# Altium schematic sheet MA730GQ.SchDoc
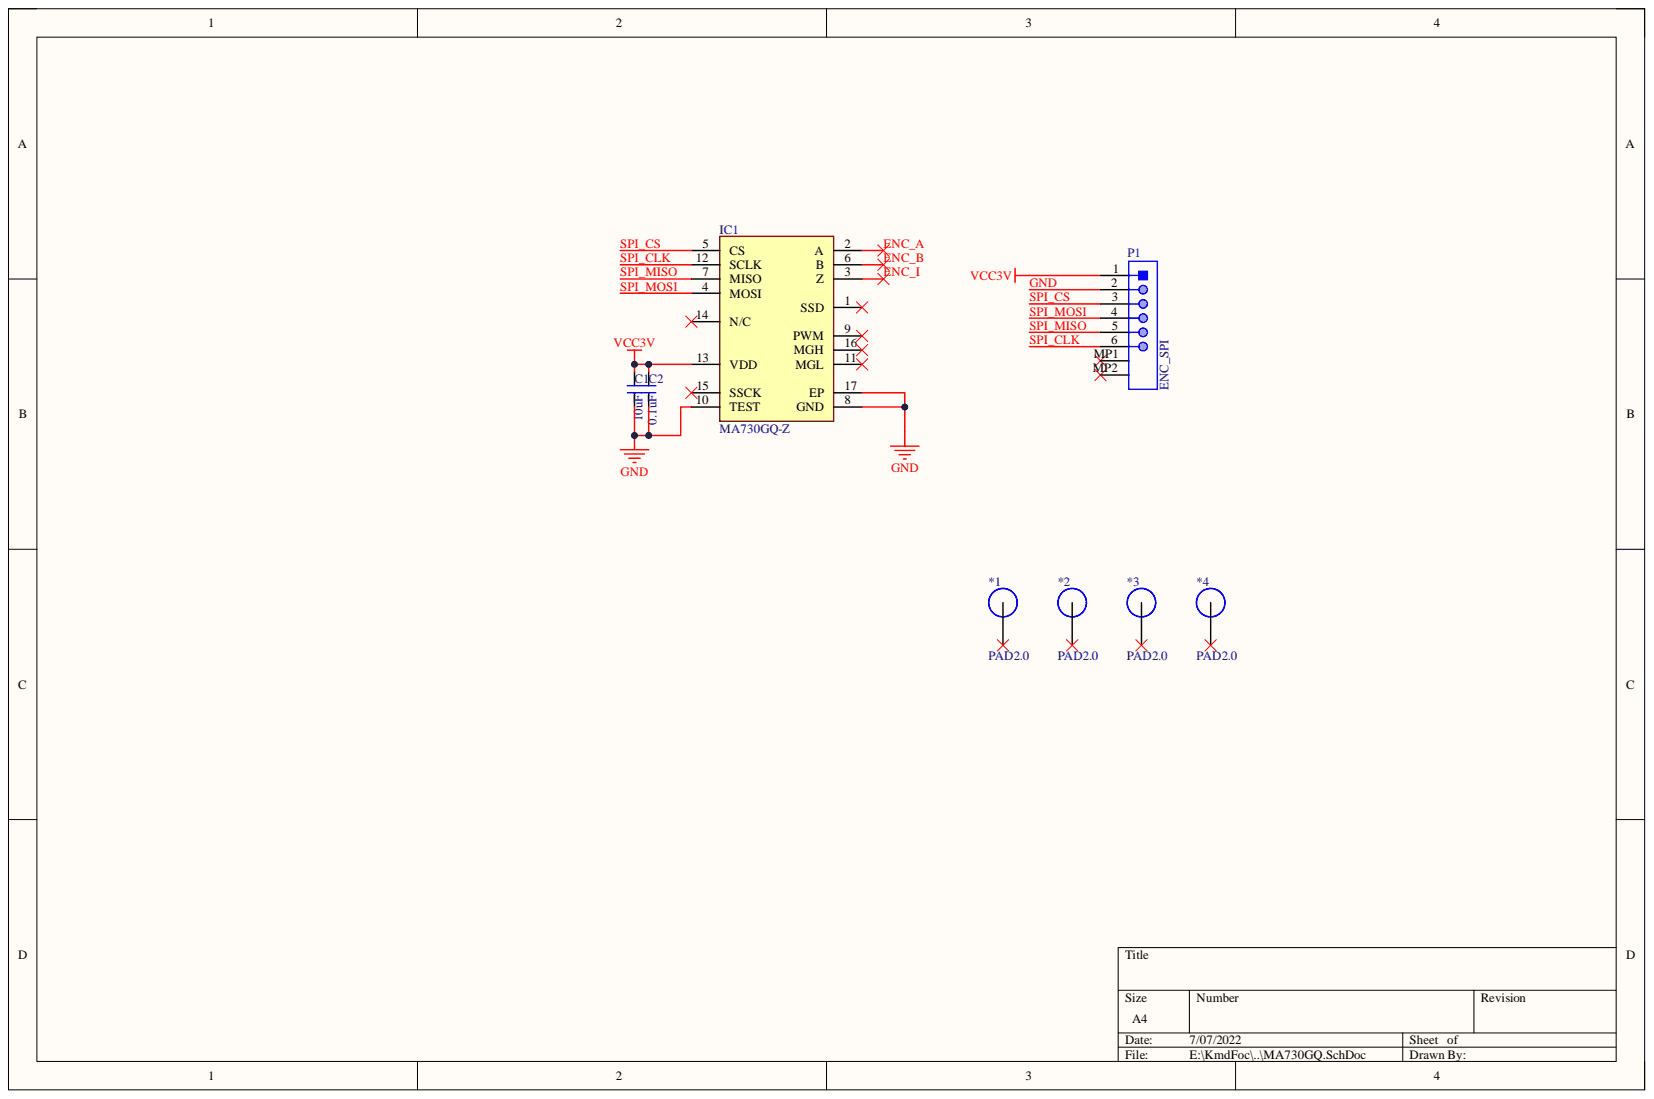
[diagram p0: MA730GQ.SchDoc]

## Parts
*1  PAD2.0  [PAD2.0]
*2  PAD2.0  [PAD2.0]
*3  PAD2.0  [PAD2.0]
*4  PAD2.0  [PAD2.0]
C1  10uF  [C 0402_L]
C2  0.1uF  [C 0402_L]
IC1  MA730GQ-Z  [QFN50P300X300X100-17N-D]
P1  ENC_SPI  [JST-SM06B-SRSS-TB_V]

## Nets
VCC3V: C1.2 C2.2 IC1.13(VDD) P1.1
ENC_A: IC1.2(A)
ENC_B: IC1.6(B)
ENC_I: IC1.3(Z)
GND: C1.1 C2.1 IC1.8(GND) IC1.10(TEST) IC1.17(EP) P1.2
SPI_CLK: IC1.12(SCLK) P1.6
SPI_CS: IC1.5(CS) P1.3
SPI_MISO: IC1.7(MISO) P1.5
SPI_MOSI: IC1.4(MOSI) P1.4

Not connected: *1 0; *2 0; *3 0; *4 0; IC1 1(SSD) 9(PWM) 11(MGL) 14(N/C) 15(SSCK) 16(MGH); P1 MP1 MP2

## Library data of the parts
C1 C2 = C: 贴片电容; Component Kind: Standard; Library Name: 0.1 - 电阻-电容-电感.SchLib
IC1 = MA730GQ-Z: Integrated Circuit; Manufacturer_Name: Monolithic Power Systems (MPS); Component Kind: Standard; Datasheet Link: https://www.monolithicpower.com/pub/media/document/m/a/ma730_r1.01.pdf; Height: 1mm; Manufacturer_Part_Number: MA730GQ-Z; Mouser Part Number: 946-MA730GQ-Z; Mouser Price/Stock: https://www.mouser.co.uk/ProductDetail/Monolithic-Power-Systems-MPS/MA730GQ-Z?qs=YCa%2FAAYMW01z3klrRj76PA%3D%3D; Library Name: vSeasky.SchLib
P1 = SM06B-SRSS-TB(LF)(SN): Male Header, Pitch 1 mm, 1 x 6 Position, Height 2.9 mm, -25 to 85 degC, RoHS, Tape and Reel; Manufacturer: JST; No of Positions: 6; Pitch: 1mm; Style/Orientation: RightAngleHeader/Plug; Component Kind: Standard; Datasheet URL: http://www.jst-mfg.com/product/pdf/eng/eSR.pdf; Datasheet Version: 05/2016; Library Name: JST SH Connector.IntLib; Manufacturer URL: http://www.jst.com/home2.html; Mounting Technology: SurfaceMount; Package Description: Male Header, Pitch 1 mm, 1 X 6 Position, Height 2.9 mm; Package Reference: SM06B-SRSS-TB(LF)(SN); Termination: Solder; Type: ShroudedHeader
*1-*4 = PAD2.0: Component Kind: Standard; Library Name: mx_pcb_library.SchLib
